# Altium schematic sheet TUN7_Main.SchDoc(TUN7_Main)
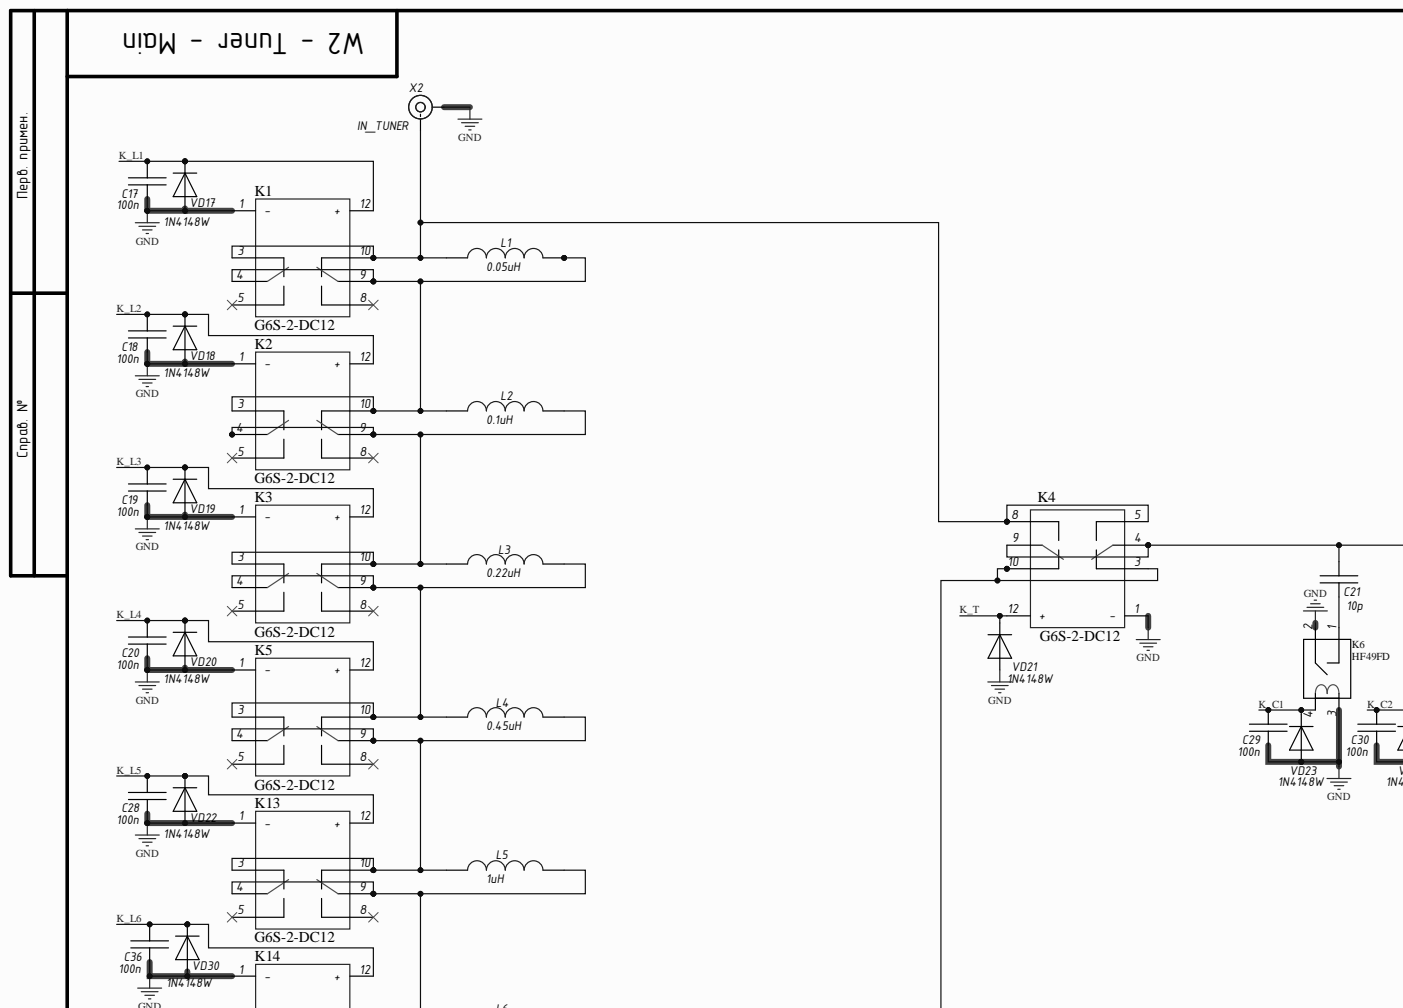
[diagram p0: TUN7_Main.SchDoc, top left]
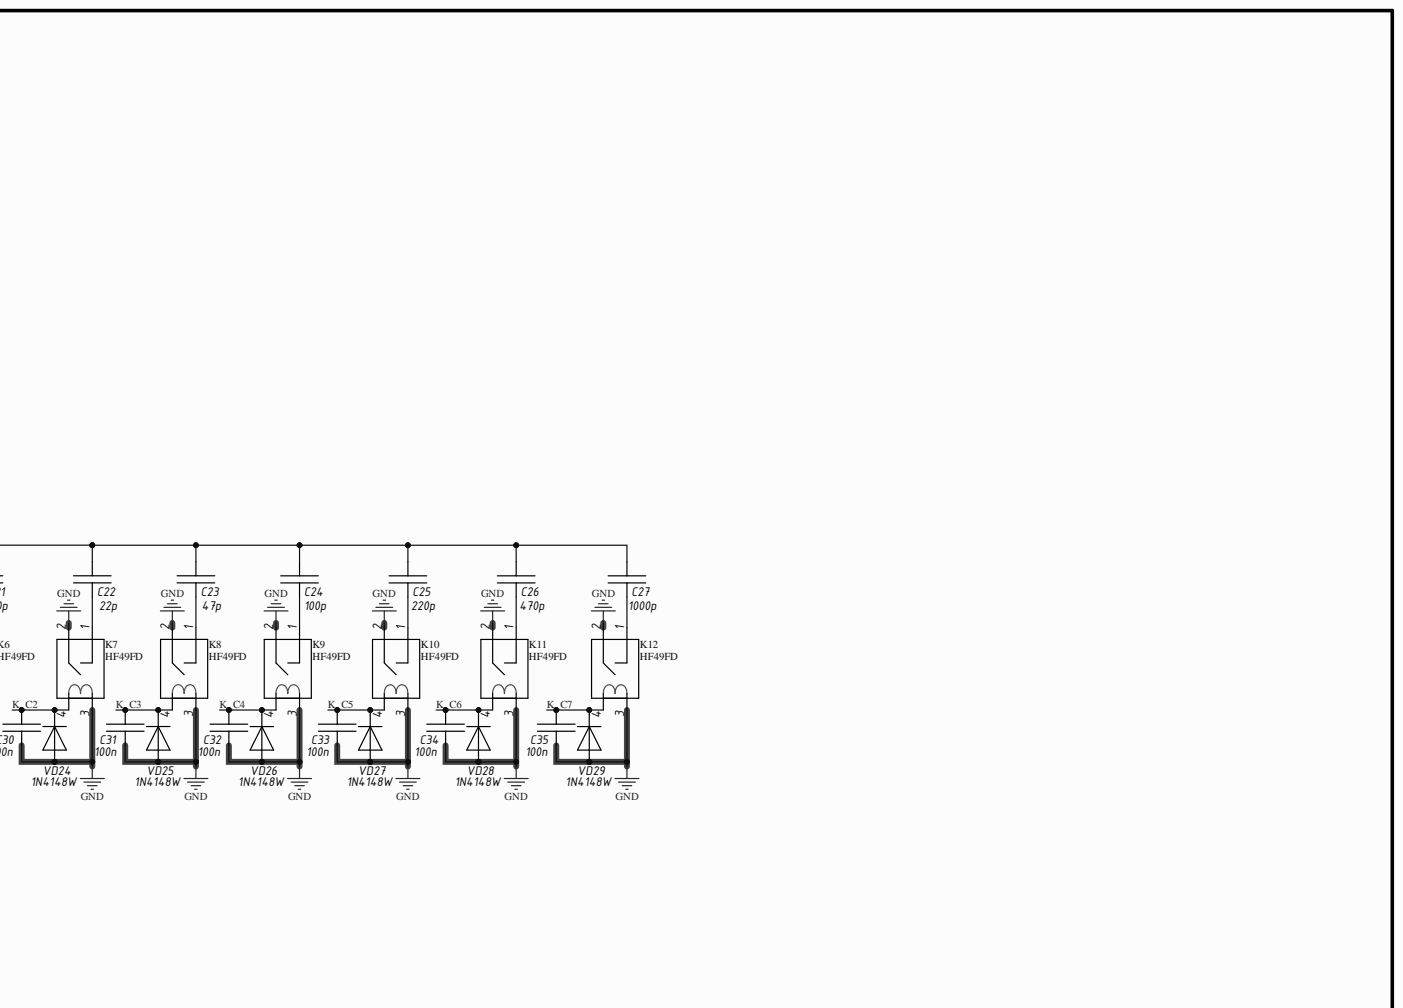
[diagram p1: TUN7_Main.SchDoc, top right]
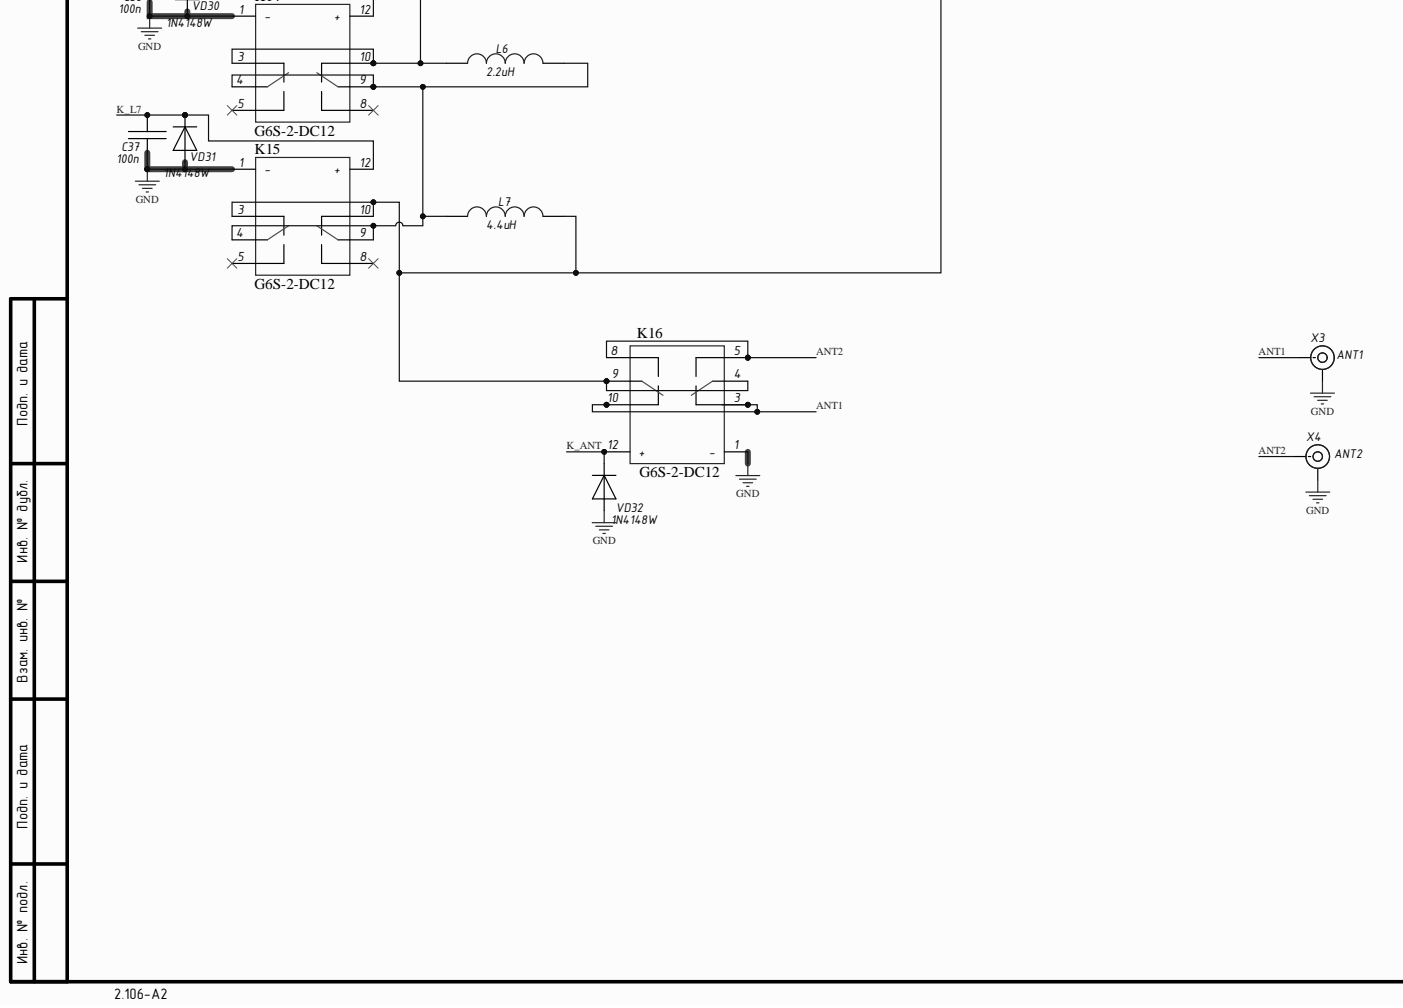
[diagram p2: TUN7_Main.SchDoc, bottom left]
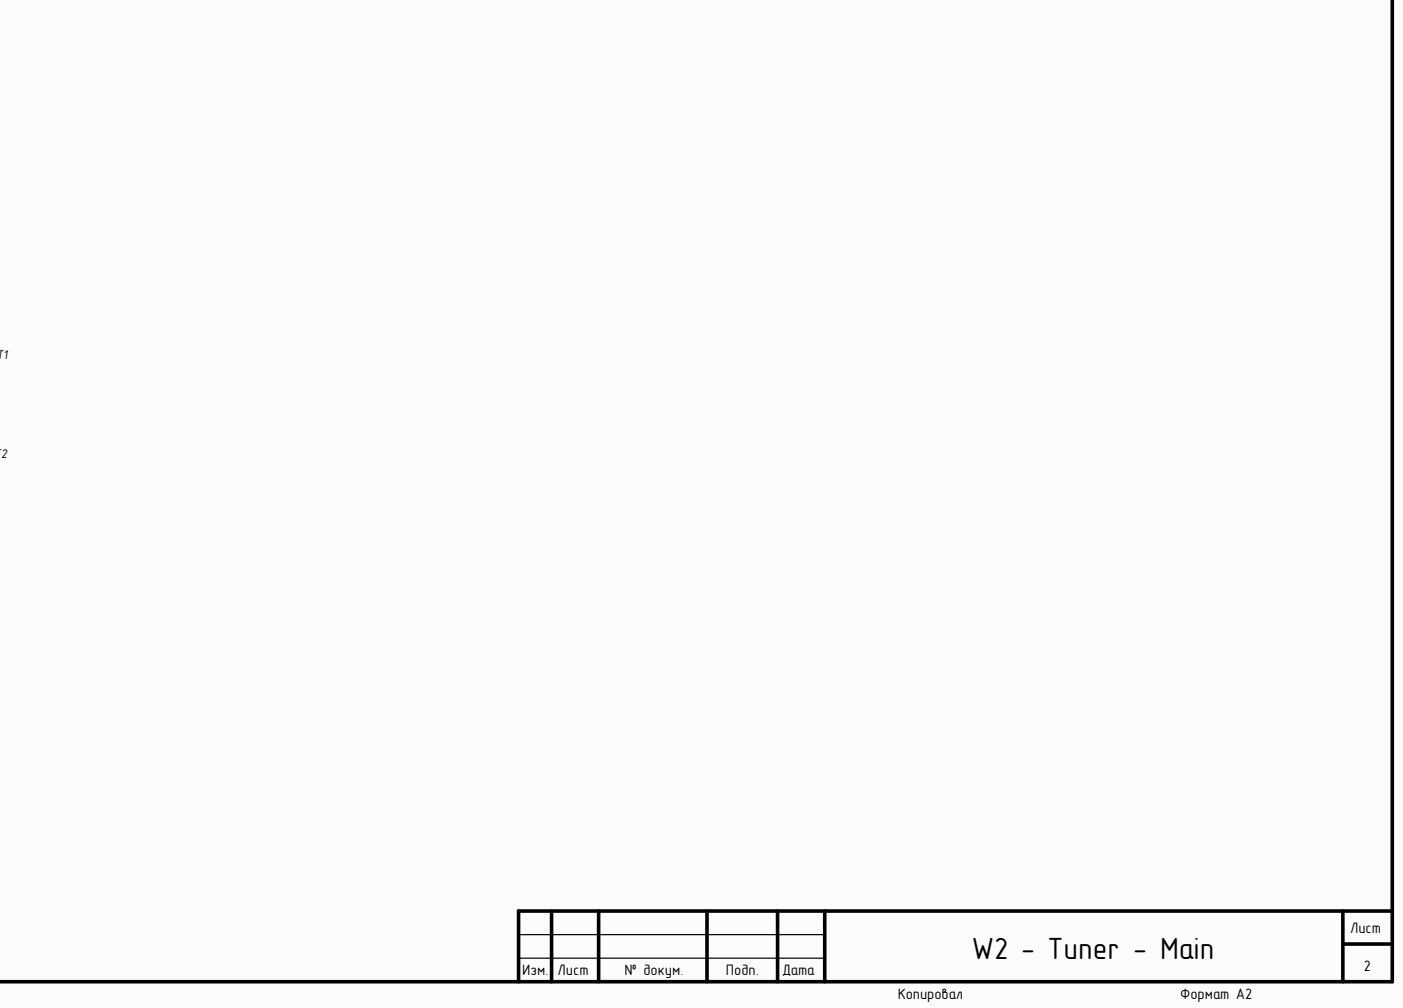
[diagram p3: TUN7_Main.SchDoc, bottom right]

## Parts
C17  100n  [CH0805C]
C18  100n  [CH0805C]
C19  100n  [CH0805C]
C20  100n  [CH0805C]
C21  10p  [CH1206ATC]
C22  22p  [CH1206ATC]
C23  47p  [CH1206ATC]
C24  100p  [CH1206ATC]
C25  220p  [CH1206ATC]
C26  470p  [CH1206ATC]
C27  1000p  [CH1206ATC]
C28  100n  [CH0805C]
C29  100n  [CH0805C]
C30  100n  [CH0805C]
C31  100n  [CH0805C]
C32  100n  [CH0805C]
C33  100n  [CH0805C]
C34  100n  [CH0805C]
C35  100n  [CH0805C]
C36  100n  [CH0805C]
C37  100n  [CH0805C]
K1  G6S-2-DC12  [G6S-2-DC12]
K2  G6S-2-DC12  [G6S-2-DC12]
K3  G6S-2-DC12  [G6S-2-DC12]
K4  G6S-2-DC12  [G6S-2-DC12]
K5  G6S-2-DC12  [G6S-2-DC12]
K6  HF49FD  [HF49FD]
K7  HF49FD  [HF49FD]
K8  HF49FD  [HF49FD]
K9  HF49FD  [HF49FD]
K10  HF49FD  [HF49FD]
K11  HF49FD  [HF49FD]
K12  HF49FD  [HF49FD]
K13  G6S-2-DC12  [G6S-2-DC12]
K14  G6S-2-DC12  [G6S-2-DC12]
K15  G6S-2-DC12  [G6S-2-DC12]
K16  G6S-2-DC12  [G6S-2-DC12]
L1  0.05uH  [DR1]
L2  0.1uH  [DR1]
L3  0.22uH  [DR1]
L4  0.45uH  [T68-0]
L5  1uH  [T68-0]
L6  2.2uH  [T68-0]
L7  4.4uH  [T68-0]
VD17  1N4148W  [SOD123]
VD18  1N4148W  [SOD123]
VD19  1N4148W  [SOD123]
VD20  1N4148W  [SOD123]
VD21  1N4148W  [SOD123]
VD22  1N4148W  [SOD123]
VD23  1N4148W  [SOD123]
VD24  1N4148W  [SOD123]
VD25  1N4148W  [SOD123]
VD26  1N4148W  [SOD123]
VD27  1N4148W  [SOD123]
VD28  1N4148W  [SOD123]
VD29  1N4148W  [SOD123]
VD30  1N4148W  [SOD123]
VD31  1N4148W  [SOD123]
VD32  1N4148W  [SOD123]
X2  IN_TUNER  [CON: SMA]
X3  ANT1  [CON: SMA]
X4  ANT2  [CON: SMA]

## Nets
GND: C17.2 C18.2 C19.2 C20.2 C28.2 C29.2 C30.2 C31.2 C32.2 C33.2 C34.2 C35.2 C36.2 C37.2 K1.1(-) K2.1(-) K3.1(-) K4.1(-) K5.1(-) K6.2 K6.3 K7.2 K7.3 K8.2 K8.3 K9.2 K9.3 K10.2 K10.3 K11.2 K11.3 K12.2 K12.3 K13.1(-) K14.1(-) K15.1(-) K16.1(-) VD17.1 VD18.1 VD19.1 VD20.1 VD21.1 VD22.1 VD23.1 VD24.1 VD25.1 VD26.1 VD27.1 VD28.1 VD29.1 VD30.1 VD31.1 VD32.1 X2.2 X3.2 X4.2
ANT1: K16.3 K16.10 X3.1
ANT2: K16.5 K16.8 X4.1
K_ANT: K16.12(+) VD32.2
K_C1: C29.1 K6.4 VD23.2
K_C2: C30.1 K7.4 VD24.2
K_C3: C31.1 K8.4 VD25.2
K_C4: C32.1 K9.4 VD26.2
K_C5: C33.1 K10.4 VD27.2
K_C6: C34.1 K11.4 VD28.2
K_C7: C35.1 K12.4 VD29.2
K_L1: C17.1 K1.12(+) VD17.2
K_L2: C18.1 K2.12(+) VD18.2
K_L3: C19.1 K3.12(+) VD19.2
K_L4: C20.1 K5.12(+) VD20.2
K_L5: C28.1 K13.12(+) VD22.2
K_L6: C36.1 K14.12(+) VD30.2
K_L7: C37.1 K15.12(+) VD31.2
K_T: K4.12(+) VD21.2
NetC21_1: C21.1 C22.1 C23.1 C24.1 C25.1 C26.1 C27.1 K4.4 K4.9
NetC21_2: C21.2 K6.1
NetC22_2: C22.2 K7.1
NetC23_2: C23.2 K8.1
NetC24_2: C24.2 K9.1
NetC25_2: C25.2 K10.1
NetC26_2: C26.2 K11.1
NetC27_2: C27.2 K12.1
NetK1_3: K1.3 K1.10 K4.5 K4.8 L1.2 X2.1
NetK1_4: K1.4 K1.9 K2.3 K2.10 L1.1 L2.2
NetK2_4: K2.4 K2.9 K3.3 K3.10 L2.1 L3.2
NetK3_4: K3.4 K3.9 K5.3 K5.10 L3.1 L4.2
NetK4_3: K4.3 K4.10 K15.3 K15.10 K16.4 K16.9 L7.1
NetK5_4: K5.4 K5.9 K13.3 K13.10 L4.1 L5.2
NetK13_4: K13.4 K13.9 K14.3 K14.10 L5.1 L6.2
NetK14_4: K14.4 K14.9 K15.4 K15.9 L6.1 L7.2

Not connected: K1 5 8; K2 5 8; K3 5 8; K5 5 8; K13 5 8; K14 5 8; K15 5 8

## Library data of the parts
C17-C37 = Capacitor: Component Kind: Standard; Library Name: Discrete.SchLib
K1-K5 K13-K16 = G6S-2-12VDC: Component Kind: Standard; Library Name: RU4PN.SchLib
K6-K12 = HF49FD 012-1H12: Component Kind: Standard; Library Name: Relay.SchLib
L1-L7 = Inductor ring: Value: {Value}; Component Kind: Standard; Library Name: Transformers.SchLib
VD17-VD32 = Diod: Component Kind: Standard; Library Name: Diods.SchLib
X2-X4 = SMA: RF; Component Kind: Standard; Library Name: Connectors.SchLib
